# Altium schematic sheet IO_Connector.SchDoc(IO_Connector)
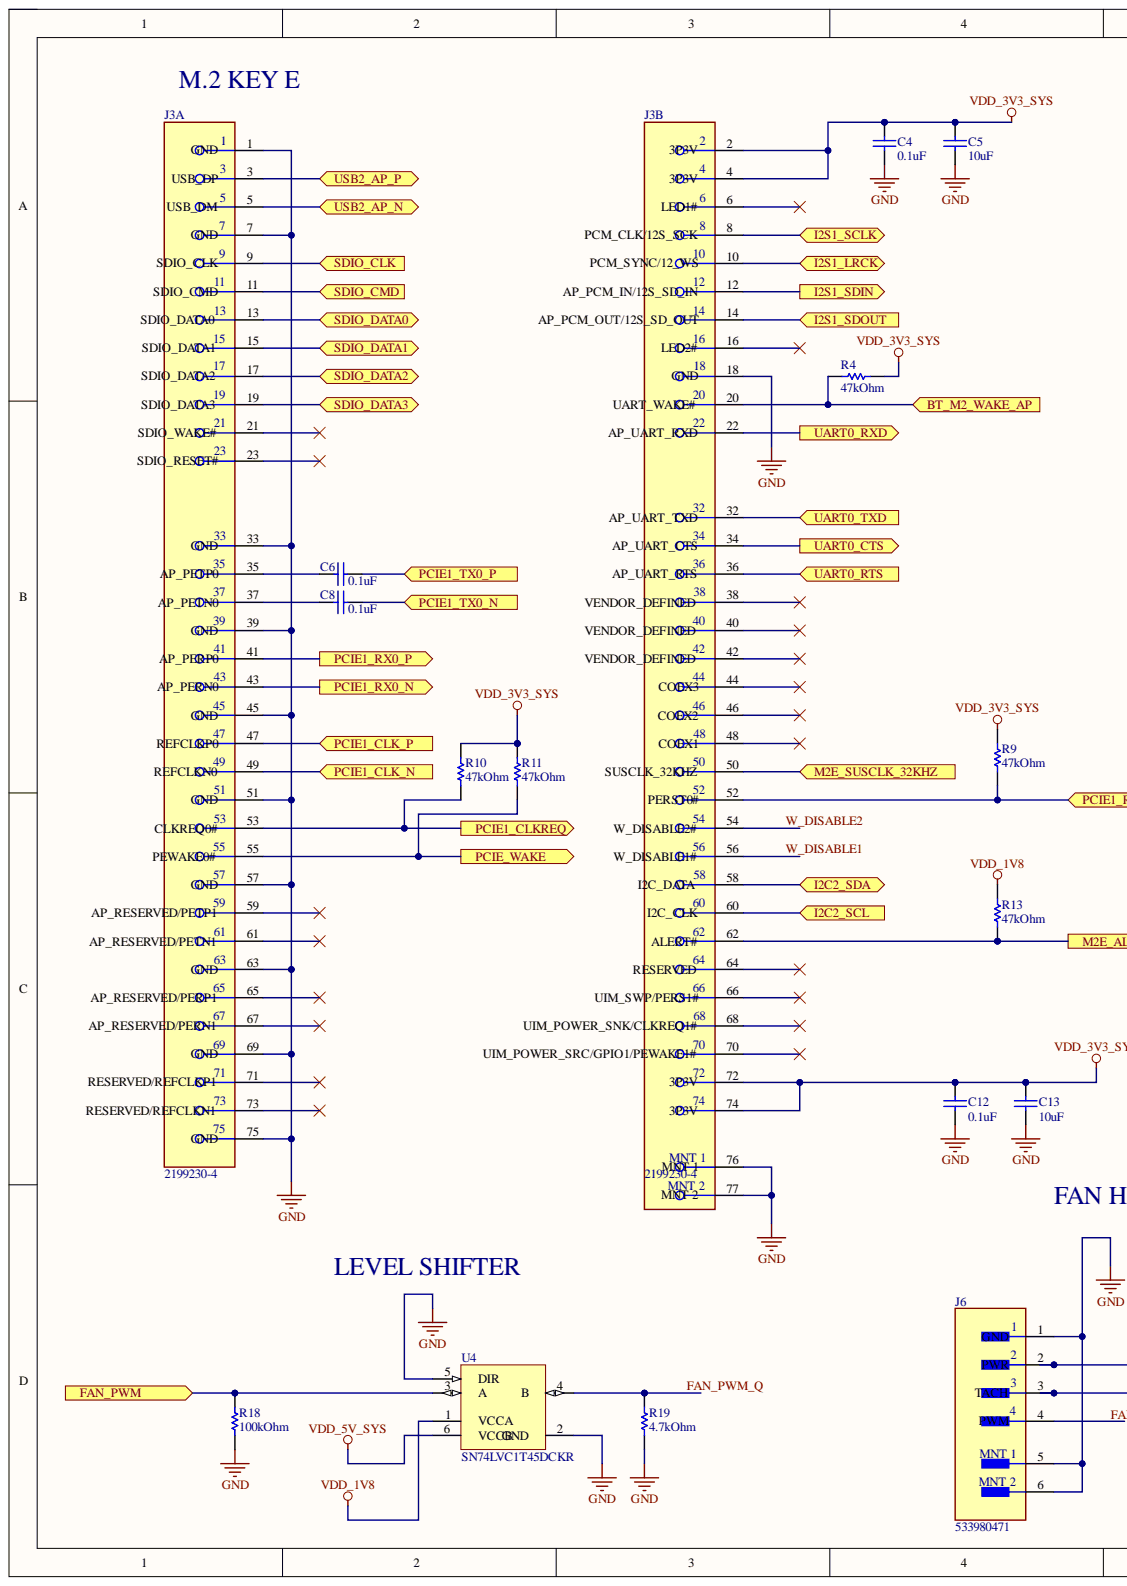
[diagram p0: IO_Connector.SchDoc, left]
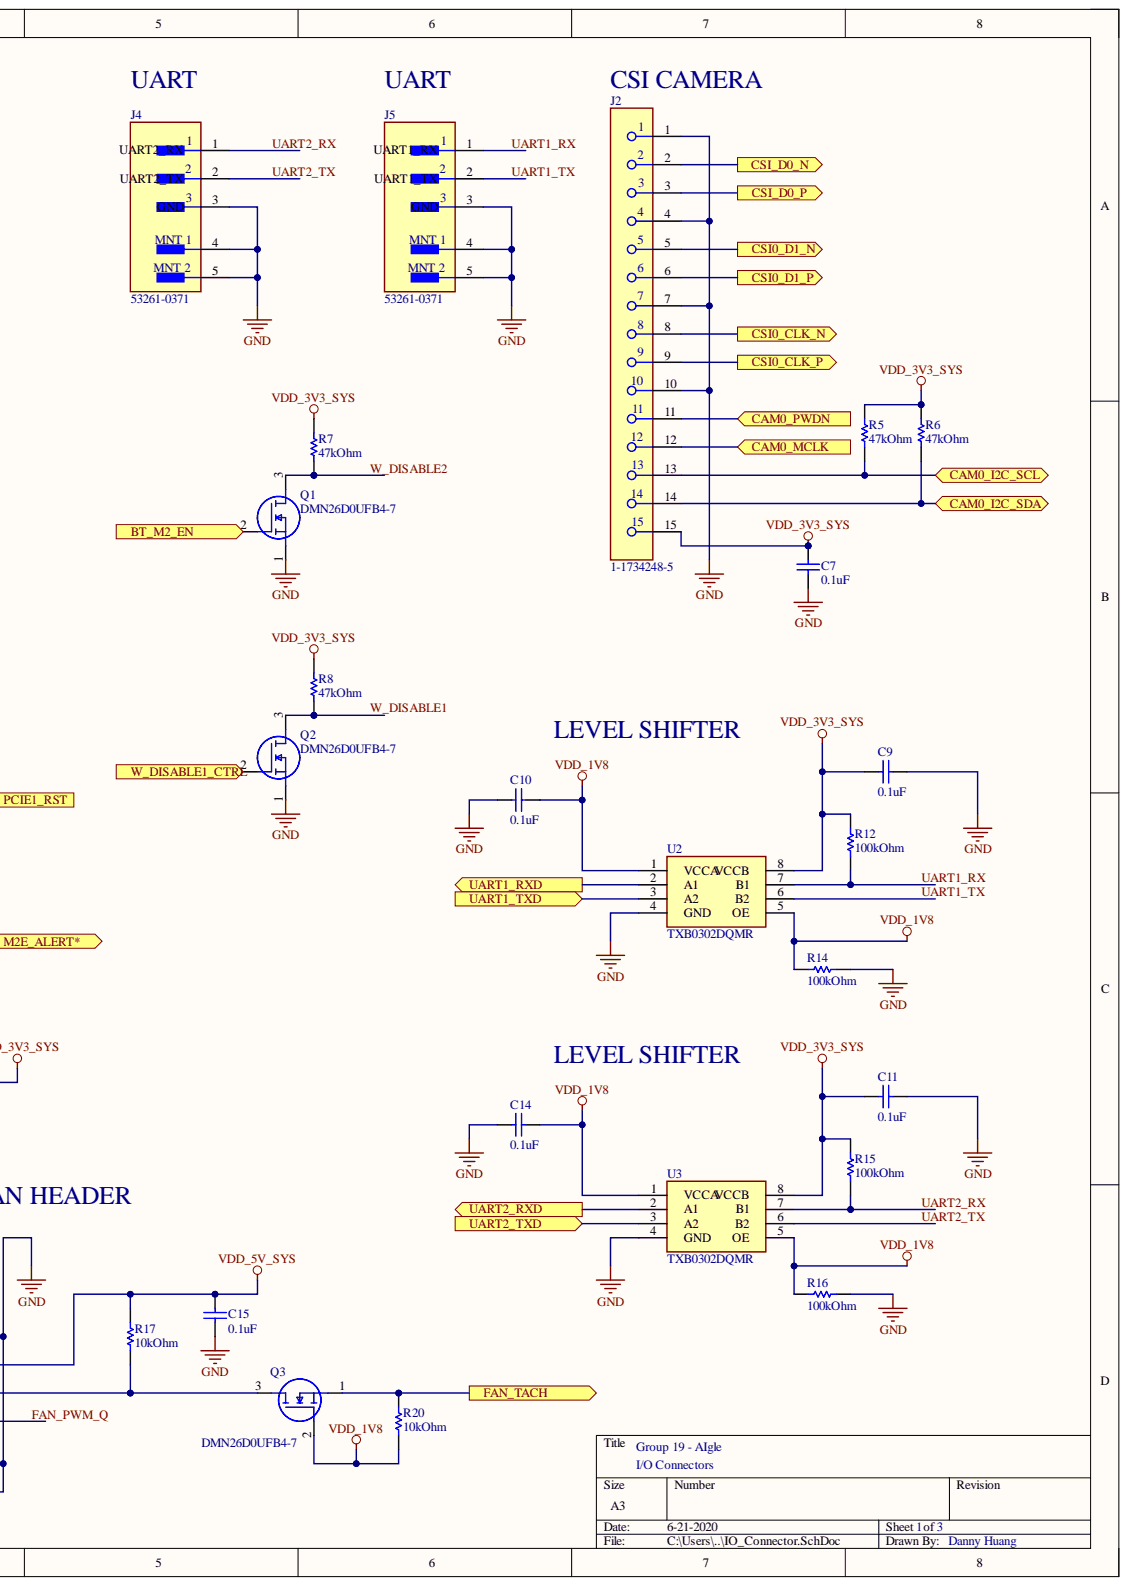
[diagram p1: IO_Connector.SchDoc, right]

## Parts
C4  0.1uF  [CAPC1005X55X30NL05T10]
C5  10uF  [CAPC1608X90X35NL10T15]
C6  0.1uF  [CAPC1005X55X30NL05T10]
C7  0.1uF  [CAPC1005X55X30NL05T10]
C8  0.1uF  [CAPC1005X55X30NL05T10]
C9  0.1uF  [CAPC1005X55X30NL05T10]
C10  0.1uF  [CAPC1005X55X30NL05T10]
C11  0.1uF  [CAPC1005X55X30NL05T10]
C12  0.1uF  [CAPC1005X55X30NL05T10]
C13  10uF  [CAPC1608X90X35NL10T15]
C14  0.1uF  [CAPC1005X55X30NL05T10]
C15  0.1uF  [CAPC1005X55X30NL05T10]
J2  1-1734248-5  [FP-1-1734248-5-MFG]
J3  2199230-4  [FP-2199230-4-MFG]  (parts A,B)
J4  53261-0371  [FP-53261-0371-MFG]
J5  53261-0371  [FP-53261-0371-MFG]
J6  533980471  [FP-533980471-MFG]
Q1  DMN26D0UFB4-7  [DMN26D0UFB47]
Q2  DMN26D0UFB4-7  [DMN26D0UFB47]
Q3  DMN26D0UFB4-7  [DMN26D0UFB47]
R4  47kOhm  [RESC1005X40X25LL05T05]
R5  47kOhm  [RESC1005X40X25LL05T05]
R6  47kOhm  [RESC1005X40X25LL05T05]
R7  47kOhm  [RESC1005X40X25LL05T05]
R8  47kOhm  [RESC1005X40X25LL05T05]
R9  47kOhm  [RESC1005X40X25LL05T05]
R10  47kOhm  [RESC1005X40X25LL05T05]
R11  47kOhm  [RESC1005X40X25LL05T05]
R12  100kOhm  [RESC1005X40X25ML05T05]
R13  47kOhm  [RESC1005X40X25LL05T05]
R14  100kOhm  [RESC1005X40X25ML05T05]
R15  100kOhm  [RESC1005X40X25ML05T05]
R16  100kOhm  [RESC1005X40X25ML05T05]
R17  10kOhm  [RESC1005X40X25NL05T05]
R18  100kOhm  [RESC1005X40X25ML05T05]
R19  4.7kOhm  [RESC1005X40X25ML05T05]
R20  10kOhm  [RESC1005X40X25NL05T05]
U2  TXB0302DQMR  [SON40P120X180X40-8N]
U3  TXB0302DQMR  [SON40P120X180X40-8N]
U4  SN74LVC1T45DCKR  [DCK0006A_L]

## Ports
BT_M2_EN, BT_M2_WAKE_AP, CAM0_I2C_SCL, CAM0_I2C_SDA, CAM0_MCLK, CAM0_PWDN, CSI0_CLK_N, CSI0_CLK_P, CSI0_D1_N, CSI0_D1_P, CSI_D0_N, CSI_D0_P, FAN_PWM, FAN_TACH, I2C2_SCL, I2C2_SDA, I2S1_LRCK, I2S1_SCLK, I2S1_SDIN, I2S1_SDOUT, M2E_ALERT*, M2E_SUSCLK_32KHZ, PCIE1_CLK_N, PCIE1_CLK_P, PCIE1_CLKREQ, PCIE1_RST, PCIE1_RX0_N, PCIE1_RX0_P, PCIE1_TX0_N, PCIE1_TX0_P, PCIE_WAKE, SDIO_CLK, SDIO_CMD, SDIO_DATA0, SDIO_DATA1, SDIO_DATA2, SDIO_DATA3, UART0_CTS, UART0_RTS, UART0_RXD, UART0_TXD, UART1_RXD, UART1_TXD, UART2_RXD, UART2_TXD, USB2_AP_N, USB2_AP_P, W_DISABLE1_CTRL

## Nets
GND: C4.1 C5.1 C7.1 C9.1 C10.2 C11.1 C12.1 C13.1 C14.2 C15.2 J2.1 J2.4 J2.7 J2.10 J3.1(GND) J3.7(GND) J3.18(GND) J3.33(GND) J3.39(GND) J3.45(GND) J3.51(GND) J3.57(GND) J3.63(GND) J3.69(GND) J3.75(GND) J3.76(MNT_1) J3.77(MNT_2) J4.3(GND) J4.4 J4.5 J5.3(GND) J5.4 J5.5 J6.1(GND) J6.5 J6.6 Q1.1 Q2.1 R14.1 R16.1 R18.2 R19.1 U2.4(GND) U3.4(GND) U4.2(GND) U4.5(DIR)
VDD_1V8: C10.1 C14.1 Q3.2 R13.2 R14.2 R16.2 R20.1 U2.1(VCCA) U2.5(OE) U3.1(VCCA) U3.5(OE) U4.1(VCCA)
VDD_3V3_SYS: C4.2 C5.2 C7.2 C9.2 C11.2 C12.2 C13.2 J2.15 J3.2(3P3V) J3.4(3P3V) J3.72(3P3V) J3.74(3P3V) R4.1 R5.2 R6.2 R7.2 R8.2 R9.2 R10.2 R11.2 R12.2 R15.2 U2.8(VCCB) U3.8(VCCB)
VDD_5V_SYS: C15.1 J6.2(PWR) R17.2 U4.6(VCCB)
BT_M2_EN: Q1.2 ; port BT_M2_EN
BT_M2_WAKE_AP: J3.20 R4.2 ; port BT_M2_WAKE_AP
CAM0_I2C_SCL: J2.13 R5.1 ; port CAM0_I2C_SCL
CAM0_I2C_SDA: J2.14 R6.1 ; port CAM0_I2C_SDA
CAM0_MCLK: J2.12 ; port CAM0_MCLK
CAM0_PWDN: J2.11 ; port CAM0_PWDN
CSI0_CLK_N: J2.8 ; port CSI0_CLK_N
CSI0_CLK_P: J2.9 ; port CSI0_CLK_P
CSI0_D1_N: J2.5 ; port CSI0_D1_N
CSI0_D1_P: J2.6 ; port CSI0_D1_P
CSI_D0_N: J2.2 ; port CSI_D0_N
CSI_D0_P: J2.3 ; port CSI_D0_P
FAN_PWM: R18.1 U4.3(A) ; port FAN_PWM
FAN_PWM_Q: J6.4(PWM) R19.2 U4.4(B)
FAN_TACH: Q3.1 R20.2 ; port FAN_TACH
I2C2_SCL: J3.60(I2C_CLK) ; port I2C2_SCL
I2C2_SDA: J3.58 ; port I2C2_SDA
I2S1_LRCK: J3.10 ; port I2S1_LRCK
I2S1_SCLK: J3.8 ; port I2S1_SCLK
I2S1_SDIN: J3.12 ; port I2S1_SDIN
I2S1_SDOUT: J3.14 ; port I2S1_SDOUT
M2E_ALERT*: J3.62(ALERT#) R13.1 ; port M2E_ALERT*
M2E_SUSCLK_32KHZ: J3.50 ; port M2E_SUSCLK_32KHZ
PCIE1_CLK_N: J3.49 ; port PCIE1_CLK_N
PCIE1_CLK_P: J3.47 ; port PCIE1_CLK_P
PCIE1_CLKREQ: J3.53 R10.1 ; port PCIE1_CLKREQ
PCIE1_RST: J3.52(PERST0#) R9.1 ; port PCIE1_RST
PCIE1_RX0_N: J3.43 ; port PCIE1_RX0_N
PCIE1_RX0_P: J3.41(AP_PERP0) ; port PCIE1_RX0_P
PCIE1_TX0_N: C8.1 ; port PCIE1_TX0_N
PCIE1_TX0_P: C6.1 ; port PCIE1_TX0_P
PCIE_WAKE: J3.55 R11.1 ; port PCIE_WAKE
SDIO_CLK: J3.9 ; port SDIO_CLK
SDIO_CMD: J3.11 ; port SDIO_CMD
SDIO_DATA0: J3.13 ; port SDIO_DATA0
SDIO_DATA1: J3.15 ; port SDIO_DATA1
SDIO_DATA2: J3.17 ; port SDIO_DATA2
SDIO_DATA3: J3.19 ; port SDIO_DATA3
UART0_CTS: J3.34 ; port UART0_CTS
UART0_RTS: J3.36 ; port UART0_RTS
UART0_RXD: J3.22 ; port UART0_RXD
UART0_TXD: J3.32 ; port UART0_TXD
UART1_RX: J5.1 R12.1 U2.7(B1)
UART1_RXD: U2.2(A1) ; port UART1_RXD
UART1_TX: J5.2 U2.6(B2)
UART1_TXD: U2.3(A2) ; port UART1_TXD
UART2_RX: J4.1 R15.1 U3.7(B1)
UART2_RXD: U3.2(A1) ; port UART2_RXD
UART2_TX: J4.2 U3.6(B2)
UART2_TXD: U3.3(A2) ; port UART2_TXD
USB2_AP_N: J3.5(USB_DM) ; port USB2_AP_N
USB2_AP_P: J3.3(USB_DP) ; port USB2_AP_P
W_DISABLE1: J3.56 Q2.3 R8.1
W_DISABLE1_CTRL: Q2.2 ; port W_DISABLE1_CTRL
W_DISABLE2: J3.54 Q1.3 R7.1
NetC6_2: C6.2 J3.35(AP_PETP0)
NetC8_2: C8.2 J3.37
NetJ6_3: J6.3(TACH) Q3.3 R17.1

Not connected: J3 6(LED1#) 16(LED2#) 21 23 38 40 42 44(COEX3) 46(COEX2) 48(COEX1) 59 61 64 65 66 67 68 70 71 73

## Library data of the parts
C4 C6-C12 C14 C15 = CMP-2008-03087-1: Manufacturer: Kemet; Part Number: C0402C104K9RACTU; Component Kind: Standard; Library Name: Altium Content Vault
C5 C13 = CMP-2006-02792-1: Manufacturer: Murata; Part Number: GRM188R60J106ME47D; Component Kind: Standard; Library Name: Altium Content Vault
J2 = CMP-03368-000001-1: CONN FPC VERT 15POS 1.00MM SMD; Manufacturer: TE; Conn Gender: Female; Conn Orientation: Vertical; Current Rating: 1A; Is Female: yes; Number Of Contacts: 15; Pitch: 1mm; Voltage Rating: 125V; Automotive: No; Category: Conn; Component Kind: Standard; ComponentLink2Description: Datasheet; ComponentLink2URL: https://www.te.com/commerce/DocumentDelivery/DDEController?Action=showdoc&DocId=Customer+Drawing%7F1734248%7FE1%7Fpdf%7FEnglish%7FENG_CD_1734248_E1__.pdf%7F1-1734248-5; Contact Material: Gold,Nickel; Device Class L1: Connectors; Device Class L2: FFC / FPC; Device Class L3: unset; Digikey Description: CONN FPC VERT 15POS 1.00MM SMD; Height: 8mm; Lead Free: Yes; Library Name: Altium Content Vault; Manufacturer Part Number: 1-1734248-5; Mounting Type: Surface Mount; Mouser Description: FFC & FPC Connectors 15P 1.0 FPC, Zip V/T SMT; Octopart: https://octopart.com/1-1734248-5-te+connectivity-40620898; Package: 0; RoHS: TRUE; Temperature: -20°C to +85°C; Temperature Range High: +85°C; Temperature Range Low: -20°C
J3 = CMP-03365-000002-1: CONN FEMALE 67POS 0.020 GOLD; Manufacturer: TE Connectivity; Conn Orientation: Right Angle; Current Rating: 0.5A; Is Female: yes; Number Of Contacts: 67; Pitch: 0.5mm; Voltage Rating: 50V; Automotive: No; Category: Conn; Component Kind: Standard; ComponentLink2Description: Datasheet; ComponentLink2URL: https://www.te.com/commerce/DocumentDelivery/DDEController?Action=showdoc&DocId=Customer+Drawing%7F2199230%7FB3%7Fpdf%7FEnglish%7FENG_CD_2199230_B3.pdf%7F2199230-4; Conn Gender: Female; Contact Material: Gold,Nickel; Device Class L1: Connectors; Device Class L2: Card Edge Connectors; Device Class L3: unset; Digikey Description: CONN FEMALE 67POS 0.020 GOLD; Height: 4.2mm; Lead Free: Yes; Library Name: Altium Content Vault; Manufacturer Part Number: 2199230-4; Mouser Description: PCI Express / PCI Connectors .5PITCH 4.2H KEY E EMBOSS NGFF MINICARD; Number Of Rows: 2; Octopart: https://octopart.com/2199230-4-te+connectivity-46436156; Package: 0; RoHS: TRUE; Temperature: -40°C to +80°C; Temperature Range High: +80°C; Temperature Range Low: -40°C
J4 J5 = CMP-04776-000071-1: CONN HEADER SMD R/A 3POS 1.25MM; Manufacturer: Molex; Conn Gender: Male; Conn Orientation: Right Angle; Current Rating: 1A; Number Of Contacts: 3; Voltage Rating: 125V; Ambient Temperature Range High: +105°C; Ambient Temperature Range Low: -40°C; Automotive: No; Category: Conn; Component Kind: Standard; ComponentLink2Description: Datasheet; ComponentLink2URL: https://www.molex.com/webdocs/datasheets/pdf/en-us/0532610371_PCB_HEADERS.pdf; Contact Material: Tin,Nickel; Device Class L1: Connectors; Device Class L2: Headers and Wire Housings; Device Class L3: unset; Digikey Description: CONN HEADER SMD R/A 3POS 1.25MM; Footprint Url: https://www.molex.com/pdm_docs/sd/532610371_sd.pdf; Height: 3.6mm; Lead Free: Yes; Library Name: Altium Content Vault; Manufacturer Part Number: 53261-0371; Mouser Description: 1.25mm (.049") Pitch PicoBlade Header, Surface Mount, Right Angle, Lead-free, 3 Circuits; Number Of Rows: 1; Octopart: https://octopart.com/53261-0371-molex-655314; Package: 0; Pitch: 1.25mm; RoHS: TRUE; Temperature: -40°C to +105°C; Temperature Range High: +105°C; Temperature Range Low: -40°C
J6 = CMP-04776-000105-1: CONN HEADER SMD 4POS 1.25MM; Manufacturer: Molex; Conn Gender: Male; Conn Orientation: Vertical; Current Rating: 1A; Number Of Contacts: 4; Standoff Height: 0.05mm; Voltage Rating: 125V; Ambient Temperature Range High: +105°C; Ambient Temperature Range Low: -40°C; Automotive: No; Category: Conn; Component Kind: Standard; ComponentLink2Description: Datasheet; ComponentLink2URL: https://www.molex.com/pdm_docs/ps/PS-51021-024-001.pdf; Contact Material: Tin; Device Class L1: Connectors; Device Class L2: Headers and Wire Housings; Device Class L3: unset; Digikey Description: CONN HEADER SMD 4POS 1.25MM; Footprint Url: https://www.molex.com/pdm_docs/sd/533980471_sd.pdf; Height: 5.1mm; Lead Free: Yes; Library Name: Altium Content Vault; Manufacturer Part Number: 533980471; Number Of Rows: 1; Octopart: https://octopart.com/53398-0471-molex-655296; Package: 0; Pitch: 1.25mm; RoHS: TRUE; Temperature: -40°C to +105°C; Temperature Range High: +105°C; Temperature Range Low: -40°C
Q1-Q3 = DMN26D0UFB4-7: MOSFET (N-Channel); Manufacturer_Name: Diodes Inc.; Arrow Part Number: DMN26D0UFB4-7; Arrow Price/Stock: https://www.arrow.com/en/products/dmn26d0ufb4-7/diodes-incorporated; Component Kind: Standard; Datasheet Link: https://componentsearchengine.com/Datasheets/1/DMN26D0UFB4-7.pdf; Height: 0.4mm; Manufacturer_Part_Number: DMN26D0UFB4-7; Mouser Part Number: 621-DMN26D0UFB4-7; Mouser Price/Stock: https://www.mouser.co.uk/ProductDetail/Diodes-Incorporated/DMN26D0UFB4-7?qs=GWgl%252BsiMZr%252B4pznsTuF3iQ%3D%3D
R4-R11 R13 = CMP-2002-00026-1: Manufacturer: Vishay Dale; Part Number: CRCW040247K0JNED; Component Kind: Standard; Library Name: Altium Content Vault
R12 R14-R16 R18 = CMP-2002-08123-2: Chip Resistor, 100 KOhm, +/- 1%, 0.63 W, -55 to 155 degC, 0402 (1005 Metric), RoHS, Tape and Reel; Case\Package: 0402; Power Rating: 63 mW; Type (Resistor): Thick Film; Voltage Rating: 50 V; Case Code (Imperial): 0402; Case Code (Metric): 1005; Component Kind: Standard; ComponentLink1Description: Manufacturer URL; ComponentLink1URL: http://www.vishay.com/; ComponentLink2Description: Datasheet; ComponentLink2URL: http://www.vishay.com/docs/20035/dcrcwe3.pdf; DatasheetVersion: 06/2012; Height: 0.35 mm; Length: 1 mm; Library Name: Altium Content Vault; Max Operating Temperature: 155 degC; Min Operating Temperature: -55 degC; Mount: Surface Mount; Mounting Technology: Surface Mount; Package Quantity: 10000; PackageDescription: 2-Pin Surface Mount Device, Body 1 x 0.5 mm; PackageReference: 0402; Packaging: Tape and Reel; Pins: 2; Radiation Hardening: No; REACH SVHC: Unknown; Resistance: 100 kohm; RoHSCompliant: true; Tolerance: 1%; Weight: 2.3E-05 oz; Width: 0.5 mm
R17 R20 = CMP-2002-08136-1: Manufacturer: Vishay Dale; Part Number: CRCW040210K0JNED; Component Kind: Standard; Library Name: Altium Content Vault
R19 = CMP-2002-00053-1: Manufacturer: Vishay BCcomponents; Part Number: CRCW04024K70JNED; Component Kind: Standard; Library Name: Altium Content Vault
U2 U3 = TXB0302DQMR: Integrated Circuit; Manufacturer_Name: Texas Instruments; Arrow Part Number: TXB0302DQMR; Arrow Price/Stock: https://www.arrow.com/en/products/txb0302dqmr/texas-instruments; Component Kind: Standard; Datasheet Link: http://www.ti.com/lit/gpn/txb0302; Height: 0.4mm; Manufacturer_Part_Number: TXB0302DQMR; Mouser Part Number: 595-TXB0302DQMR; Mouser Price/Stock: https://www.mouser.co.uk/ProductDetail/Texas-Instruments/TXB0302DQMR?qs=5771e39Rz9HEpqtrmqNQ0Q%3D%3D
U4 = CMP-0859-00452-3: Single-Bit Dual-Supply Bus Transceiver with Configurable Voltage Translation and 3-State Outputs, DCK0006A, LARGE T&R; Manufacturer: Texas Instruments; 3-State Output: Yes; Bits(#): 1; F @ Nom Voltage(Max)(Mhz): 150; ICC @ Nom Voltage(Max)(mA): 0.004; Input Type: CMOS/TTL; Logic: True; Output Drive (IOL/IOH)(Max)(mA): 32/-32; Output Type: CMOS; Rating: Catalog; Schmitt Trigger: No; Sub-Family: Dual Supply Translator; Technology Family: LVC; tpd @ Nom Voltage(Max)(ns): 15.5,8,5.8,4.4; VCC(Max)(V): 5.5; VCC(Min)(V): 1.65; Voltage(Nom)(V): 1.8,2.5,3.3,5; Component Kind: Standard; DatasheetVersion: SCES515K; Generic Part Number: SN74LVC1T45; Library Name: Altium Content Vault; Operating Temperature Range(C): -40 to 85; PackageReference: DCK0006A
